# Altium schematic sheet Top.SchDoc
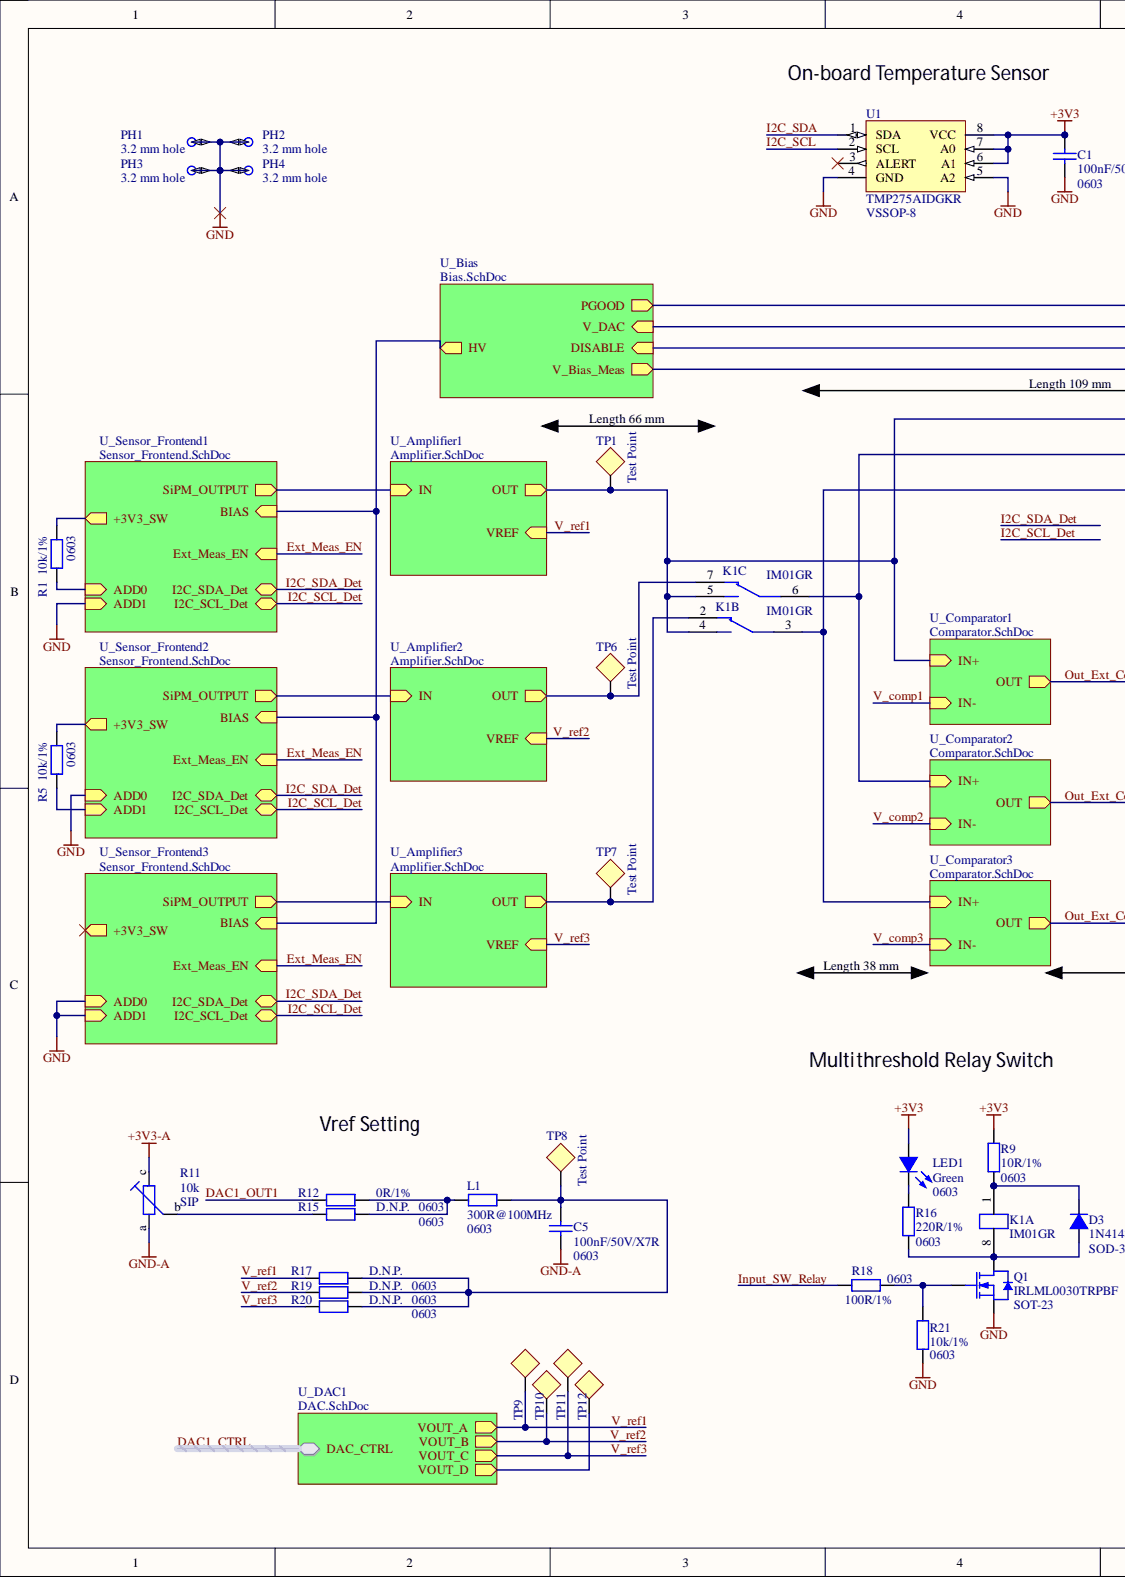
[diagram p0: Top.SchDoc, left]
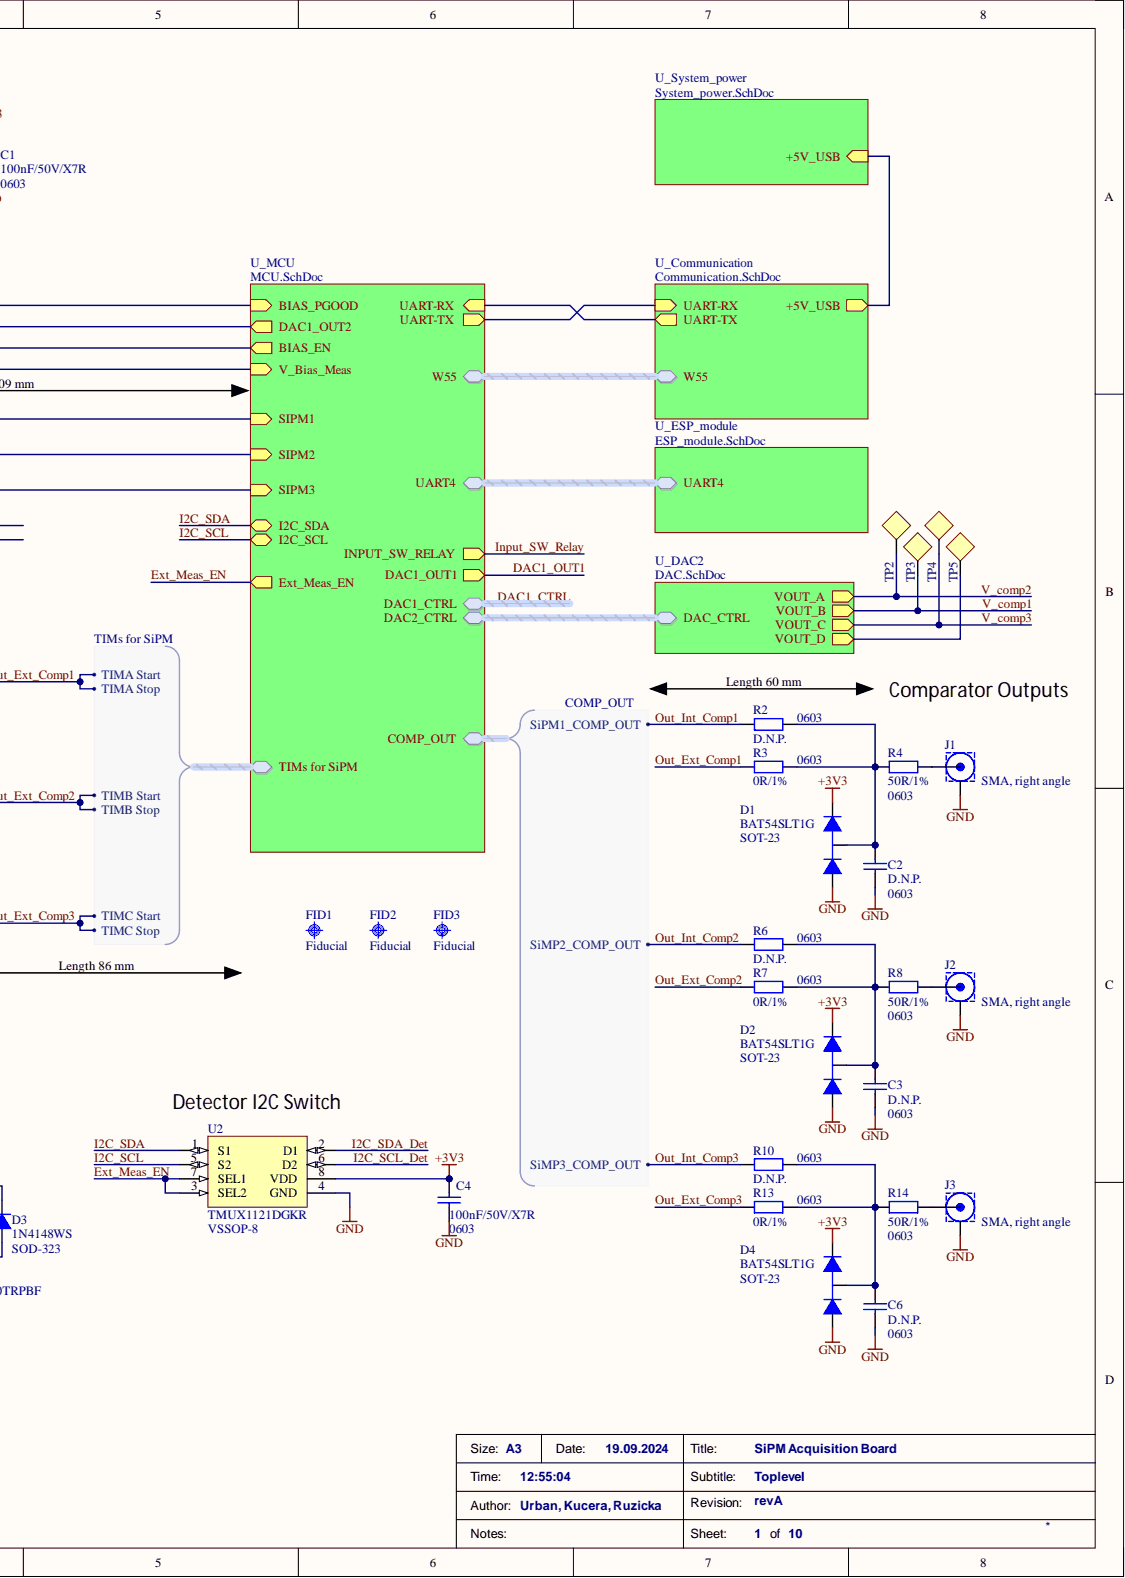
[diagram p1: Top.SchDoc, right]

## Parts
C1  100nF/50V/X7R  Package: 0603  [sm_cap_0603]
C2  D.N.P.  Package: 0603  [sm_cap_0603]
C3  D.N.P.  Package: 0603  [sm_cap_0603]
C4  100nF/50V/X7R  Package: 0603  [sm_cap_0603]
C5  100nF/50V/X7R  Package: 0603  [sm_cap_0603]
C6  D.N.P.  Package: 0603  [sm_cap_0603]
D1  BAT54SLT1G  [SOT-23]
D2  BAT54SLT1G  [SOT-23]
D3  1N4148WS  Package: SOD-323  [sm_dio_SOD323]
D4  BAT54SLT1G  [SOT-23]
FID1  Fiducial  [FIDUCIAL_TOP_S100-200]
FID2  Fiducial  [FIDUCIAL_TOP_S100-200]
FID3  Fiducial  [FIDUCIAL_TOP_S100-200]
J1  SMA, right angle  [SMA_right_angle]
J2  SMA, right angle  [SMA_right_angle]
J3  SMA, right angle  [SMA_right_angle]
K1  IM01GR  [RELAY_1462037-1]  (parts A,B,C)
L1  300R@100MHz  Package: 0603  [sm_emi_0603]
LED1  Green  Package: 0603  [LED_0603]
PH1  3.2 mm hole  [c620h320]
PH2  3.2 mm hole  [c620h320]
PH3  3.2 mm hole  [c620h320]
PH4  3.2 mm hole  [c620h320]
Q1  IRLML0030TRPBF  [SOT-23]
R1  10k/1%  Package: 0603  [sm_res_0603]
R2  D.N.P.  Package: 0603  [sm_res_0603]
R3  0R/1%  Package: 0603  [sm_res_0603]
R4  50R/1%  Package: 0603  [sm_res_0603]
R5  10k/1%  Package: 0603  [sm_res_0603]
R6  D.N.P.  Package: 0603  [sm_res_0603]
R7  0R/1%  Package: 0603  [sm_res_0603]
R8  50R/1%  Package: 0603  [sm_res_0603]
R9  10R/1%  Package: 0603  [sm_res_0603]
R10  D.N.P.  Package: 0603  [sm_res_0603]
R11  10k  Package: SIP  [Trimmer_ND]
R12  0R/1%  [sm_res_0603]
R13  0R/1%  Package: 0603  [sm_res_0603]
R14  50R/1%  Package: 0603  [sm_res_0603]
R15  D.N.P.  [sm_res_0603]
R16  220R/1%  Package: 0603  [sm_res_0603]
R17  D.N.P.  [sm_res_0603]
R18  100R/1%  Package: 0603  [sm_res_0603]
R19  D.N.P.  [sm_res_0603]
R20  D.N.P.  [sm_res_0603]
R21  10k/1%  Package: 0603  [sm_res_0603]
TP1  Test Point  [Test_point_SMD_2_mm_circular]  (no BOM)
TP2  Test Point  [Test_point_SMD_2_mm_circular]  (no BOM)
TP3  Test Point  [Test_point_SMD_2_mm_circular]  (no BOM)
TP4  Test Point  [Test_point_SMD_2_mm_circular]  (no BOM)
TP5  Test Point  [Test_point_SMD_2_mm_circular]  (no BOM)
TP6  Test Point  [Test_point_SMD_2_mm_circular]  (no BOM)
TP7  Test Point  [Test_point_SMD_2_mm_circular]  (no BOM)
TP8  Test Point  [Test_point_SMD_2_mm_circular]  (no BOM)
TP9  Test Point  [Test_point_SMD_2_mm_circular]  (no BOM)
TP10  Test Point  [Test_point_SMD_2_mm_circular]  (no BOM)
TP11  Test Point  [Test_point_SMD_2_mm_circular]  (no BOM)
TP12  Test Point  [Test_point_SMD_2_mm_circular]  (no BOM)
U1  TMP275AIDGKR  [VSSOP-8]
U2  TMUX1121DGKR  [VSSOP-8]

## Nets
+3V3: C1.2 C4.2 D1.2 D2.2 D4.2 LED1.1 R9.2 U1.6(A1) U1.7(A0) U1.8(VCC) U2.8(VDD)
+3V3-A: R11.c
GND: C1.1 C2.1 C3.1 C4.1 C6.1 D1.1 D2.1 D4.1 J1.SHIELD J2.SHIELD J3.SHIELD PH1.1 PH2.1 PH3.1 PH4.1 Q1.2 R21.1 U1.4(GND) U1.5(A2) U2.4(GND)
GND-A: C5.1 R11.a
DAC1_OUT1: R12.1
Ext_Meas_EN: U2.3(SEL2) U2.7(SEL1)
I2C_SCL: U1.2(SCL) U2.5(S2)
I2C_SCL_Det: U2.6(D2)
I2C_SDA: U1.1(SDA) U2.1(S1)
I2C_SDA_Det: U2.2(D1)
Input_SW_Relay: R18.1
Out_Ext_Comp1: R3.1
Out_Ext_Comp2: R7.1
Out_Ext_Comp3: R13.1
Out_Int_Comp1: R2.2
Out_Int_Comp2: R6.2
Out_Int_Comp3: R10.2
V_comp1: TP3.1
V_comp2: TP2.1
V_comp3: TP4.1
V_ref1: R17.2 TP9.1
V_ref2: R19.2 TP10.1
V_ref3: R20.2 TP11.1
NetC2_2: C2.2 D1.3 R2.1 R3.2 R4.2
NetC3_2: C3.2 D2.3 R6.1 R7.2 R8.2
NetC5_2: C5.2 L1.2 R17.1 R19.1 R20.1 TP8.1
NetC6_2: C6.2 D4.3 R10.1 R13.2 R14.2
NetD3_1: D3.1 K1.8 Q1.3 R16.1
NetD3_2: D3.2 K1.1 R9.1
NetJ1_CORE: J1.CORE R4.1
NetJ2_CORE: J2.CORE R8.1
NetJ3_CORE: J3.CORE R14.1
NetK1_2: K1.2 TP7.1
NetK1_3: K1.3
NetK1_4: K1.4 K1.5 TP1.1
NetK1_6: K1.6
NetK1_7: K1.7 TP6.1
NetL1_1: L1.1 R12.2 R15.1
NetLED1_2: LED1.2 R16.2
NetQ1_1: Q1.1 R18.2 R21.2
NetR1_1: R1.1
NetR1_2: R1.2
NetR5_1: R5.1
NetR5_2: R5.2
NetR11_b: R11.b R15.2
NetTP5_1: TP5.1
NetTP12_1: TP12.1
NetU1_3: U1.3(ALERT)
+3V3_SW:
+5V_USB:
BIAS:
BIAS_EN:
BIAS_PGOOD:
DAC1_OUT2:
DAC2_CTRL.DAC_I2C_SCL:
DAC2_CTRL.DAC_I2C_SDA:
DAC2_CTRL.DAC_nLDAC:
DAC2_CTRL.DAC_RDY:
IN:
UART4.UART4_CST:
UART4.UART4_RST:
UART4.UART4_RX:
UART4.UART4_TX:
UART-RX:
V_Bias_Meas:
W55.W55-~{CS}:
W55.W55-CLK:
W55.W55-~{INT}:
W55.W55-MISO:
W55.W55-MOSI:
W55.W55-~{RST}:

## Library data of the parts
C1 C4 C5 = Capacitor_0603_100n_50V_X7R: MLCC capacitor, non-polarized; Manufacturer: Yageo; Part Number: CC0603KRX7R9BB104; Component Kind: Standard; Distributor: Mouser; Library Name: RICE_local.IntLib; Link: https://cz.mouser.com/ProductDetail/Yageo/CC0603KRX7R9BB104?qs=sGAEpiMZZMvsSlwiRhF8qgFnKIgZe697WZ9nrpNTeGg%3D
C2 C3 C6 = Capacitor_0603_DNP: MLCC capacitor, non-polarized; Component Kind: Standard; Library Name: RICE_local.IntLib
D1 D2 D4 = BAT54SLT1G: Manufacturer: ON Semiconductor; Component Kind: Standard; Description: BAT54SLT1G; Distributor: Mouser; Library Name: Symbols.SchLib; Link: http://cz.mouser.com/ProductDetail/ON-Semiconductor/BAT54SLT1G/?qs=sGAEpiMZZMtQ8nqTKtFS%2fCKUxMvjsmGzmkUbaMfK3Po%3d; Package: SOT-23; Part Number: BAT54SLT1G
D3 = 1N4148WS: 75 V, 150 mA, Diode; Manufacturer: onsemi / Fairchild; Part Number: 512-1N4148WS; Component Kind: Standard; Distributor: Mouser; Library Name: Library_KEI_Tomas.IntLib; Link: https://cz.mouser.com/ProductDetail/onsemi-Fairchild/1N4148WS?qs=2%2FYqgE%252BHg%252BKBrKZlAsMLhw%3D%3D
FID1-FID3 = FIDUCIAL_TARGET_S100-200: Component Kind: Standard; Library Name: RICE_local.IntLib
J1-J3 = SMA_right_angle: Manufacturer: TE Connectivity / AMP; Part Number: 3-1478978-1; Component Kind: Standard; Description: SMA, right angle; Distributor: Mouser; Library Name: RICE_local.IntLib; Link: http://cz.mouser.com/ProductDetail/TE-Connectivity-AMP/3-1478978-1/?qs=sGAEpiMZZMuLQf%252bEuFsOrummycjJSebJ8om%252bmz%2fi%252bxY%3d; Package: SMA, right angle
K1 = IM01GR: Relay 3VDC 2A DPDT Signal Relay; Manufacturer: TE Connectivity; Component Kind: Standard; Distributor: Mouser; Library Name: Library_KEI_Ruzicka.IntLib; Link: https://cz.mouser.com/ProductDetail/TE-Connectivity-PB/IM01GR?qs=kJlCKCzaZTN1lvAfLPfsqA%3D%3D; Package: IM01GR; Part Number: IM01GR
L1 = EMI_ferrite_bead_0603_300R: EMI ferrite bead; Manufacturer: Laird; Part Number: LI0603D301R-10; Component Kind: Standard; Distributor: Mouser; Library Name: RICE_local.IntLib; Link: http://cz.mouser.com/ProductDetail/Laird-Technologies/LI0603D301R-10/?qs=sGAEpiMZZMtdyQheitOmRXJdVJiWCa9XUvsCtE%252bIu44%3d
LED1 = LED_0603_green: LED diode, SMD; Manufacturer: Wurth Elektronik; Part Number: 150060VS75000; Component Kind: Standard; Distributor: Mouser; Library Name: Library_KEI_Tomas.IntLib; Link: https://cz.mouser.com/ProductDetail/Wurth-Elektronik/150060VS75000?qs=LlUlMxKIyB1Q1Bi5mQ%2FKLw%3D%3D
PH1-PH4 = PLATED_HOLE3.2_PAD6.2: Component Kind: Standard; Library Name: RICE_local.IntLib
Q1 = IRLML0030TRPBF: N-channel MOSFET, 30 V, 5,3 A, 27 mohm; Manufacturer: INFINEON; Component Kind: Standard; Distributor: Mouser; Library Name: RICE_local.IntLib; Link: https://cz.mouser.com/ProductDetail/Infineon-Technologies/IRLML0030TRPBF?qs=%2Fha2pyFaduheTAhzJqsWt8uP6kaFFXPDIBkWUj0rWVXHN0D8P7OuGg%3D%3D; Package: SOT-23; Part Number: IRLML0030TRPBF
R1 R5 R21 = Resistor_0603_10k_1%: Chip resistor; Manufacturer: Yageo; Part Number: RC0603FR-0710KL; Component Kind: Standard; Distributor: Mouser; Library Name: RICE_local.IntLib; Link: https://cz.mouser.com/ProductDetail/Yageo/RC0603FR-0710KL?qs=sGAEpiMZZMtlubZbdhIBIAhzU1Tw%252BHVakiDuQ4Zki0U%3D
R2 R6 R10 R15 R17 R19 R20 = Resistor_0603_DNP: Chip resistor; Component Kind: Standard; Library Name: RICE_local.IntLib
  R15 R17 R19 R20: Package: 0603
R3 R7 R12 R13 = Resistor_0603_0R_1%: Chip resistor; Manufacturer: Yageo; Part Number: RC0603FR-070RL; Component Kind: Standard; Distributor: Mouser; Library Name: RICE_local.IntLib; Link: https://cz.mouser.com/ProductDetail/Yageo/RC0603FR-070RL?qs=sGAEpiMZZMvdGkrng054tx7%2F6%252BNA3LAJbOjDgY8S7mQ%3D
  R12: Package: 0603
R4 R8 R14 = Resistor_0603_50R_1%: Chip resistor; Manufacturer: Vishay; Part Number: CRCW060350R0FKEA; Component Kind: Standard; Distributor: Mouser; Library Name: RICE_local.IntLib; Link: http://cz.mouser.com/ProductDetail/Vishay/CRCW060350R0FKEA/?qs=sGAEpiMZZMvdGkrng054txCzvDpDazy7AJnNXXoMwoE%3d
R9 = Resistor_0603_10R_1%: Chip resistor; Manufacturer: Yageo; Part Number: RC0603FR-0710RL; Component Kind: Standard; Distributor: Mouser; Library Name: RICE_local.IntLib; Link: http://cz.mouser.com/ProductDetail/Yageo/RC0603FR-0710RL/?qs=sGAEpiMZZMvdGkrng054t1u4NFGr8RGr6sCAHWQAtFo%3d
R11 = Trimmer_10k: Trimmer; Manufacturer: Vishay; Part Number: T93YA103KT20; Component Kind: Standard; Distributor: Mouser; Library Name: RICE_local.IntLib; Link: https://cz.mouser.com/ProductDetail/Vishay-Sfernice/T93YA103KT20?qs=%2fha2pyFaduivxDecqHXDVnzrHzVpwpLJ098xjAsZO%2f%252bxYsvvkOXAhQ%3d%3d
R16 = Resistor_0603_220R_1%: Chip resistor; Manufacturer: Yageo; Part Number: RC0603FR-07220RL; Component Kind: Standard; Distributor: Mouser; Library Name: RICE_local.IntLib; Link: https://cz.mouser.com/ProductDetail/Yageo/RC0603FR-07220RL?qs=sGAEpiMZZMvdGkrng054t8Tx25L%252BvTaRNoHZ%252BdTMAnY%3D
R18 = Resistor_0603_100R_1%: Chip resistor; Manufacturer: Yageo; Part Number: RC0603FR-07100RL; Component Kind: Standard; Distributor: Mouser; Library Name: RICE_local.IntLib; Link: https://cz.mouser.com/ProductDetail/Yageo/RC0603FR-07100RL?qs=sGAEpiMZZMvdGkrng054txdMlaQGj9fiaLtoULAqhv0%3D
TP1-TP12 = Test_point_SMD_2_mm_circular: SMD test point, circular, 2 mm; Library Name: RICE_local.IntLib
U1 = TMP275: I2C ±0.5°C Temperature Sensor; Manufacturer: Texas Instruments; Component Kind: Standard; Distributor: Mouser; Library Name: Library_KEI_Ruzicka.IntLib; Link: https://cz.mouser.com/ProductDetail/Texas-Instruments/TMP275AIDGKR?qs=RnzODY3cU8v9LWMH5YW1eQ%3D%3D&utm_id=20109199427&gad_source=1&gclid=CjwKCAjwhIS0BhBqEiwADAUhc0_SASKqB-PLyWTqpads6ibLibyqbTwsBhDKT4CGQp2hdobwB620EhoCWvAQAvD_BwE; Package: VSSOP-8; Part Number: TMP275AIDGKR
U2 = TMUX1121: Analogue Switch, 2x SPST; Manufacturer: Texas Instruments; Component Kind: Standard; Distributor: Mouser; Library Name: Library_KEI_Ruzicka.IntLib; Link: https://cz.mouser.com/ProductDetail/Texas-Instruments/TMUX1121DGKR?qs=XeJtXLiO41RR8f%2F8dmY7lg%3D%3D; Package: VSSOP-8; Part Number: TMUX1121DGKR
